# Altium schematic sheet Power.SchDoc(Power)
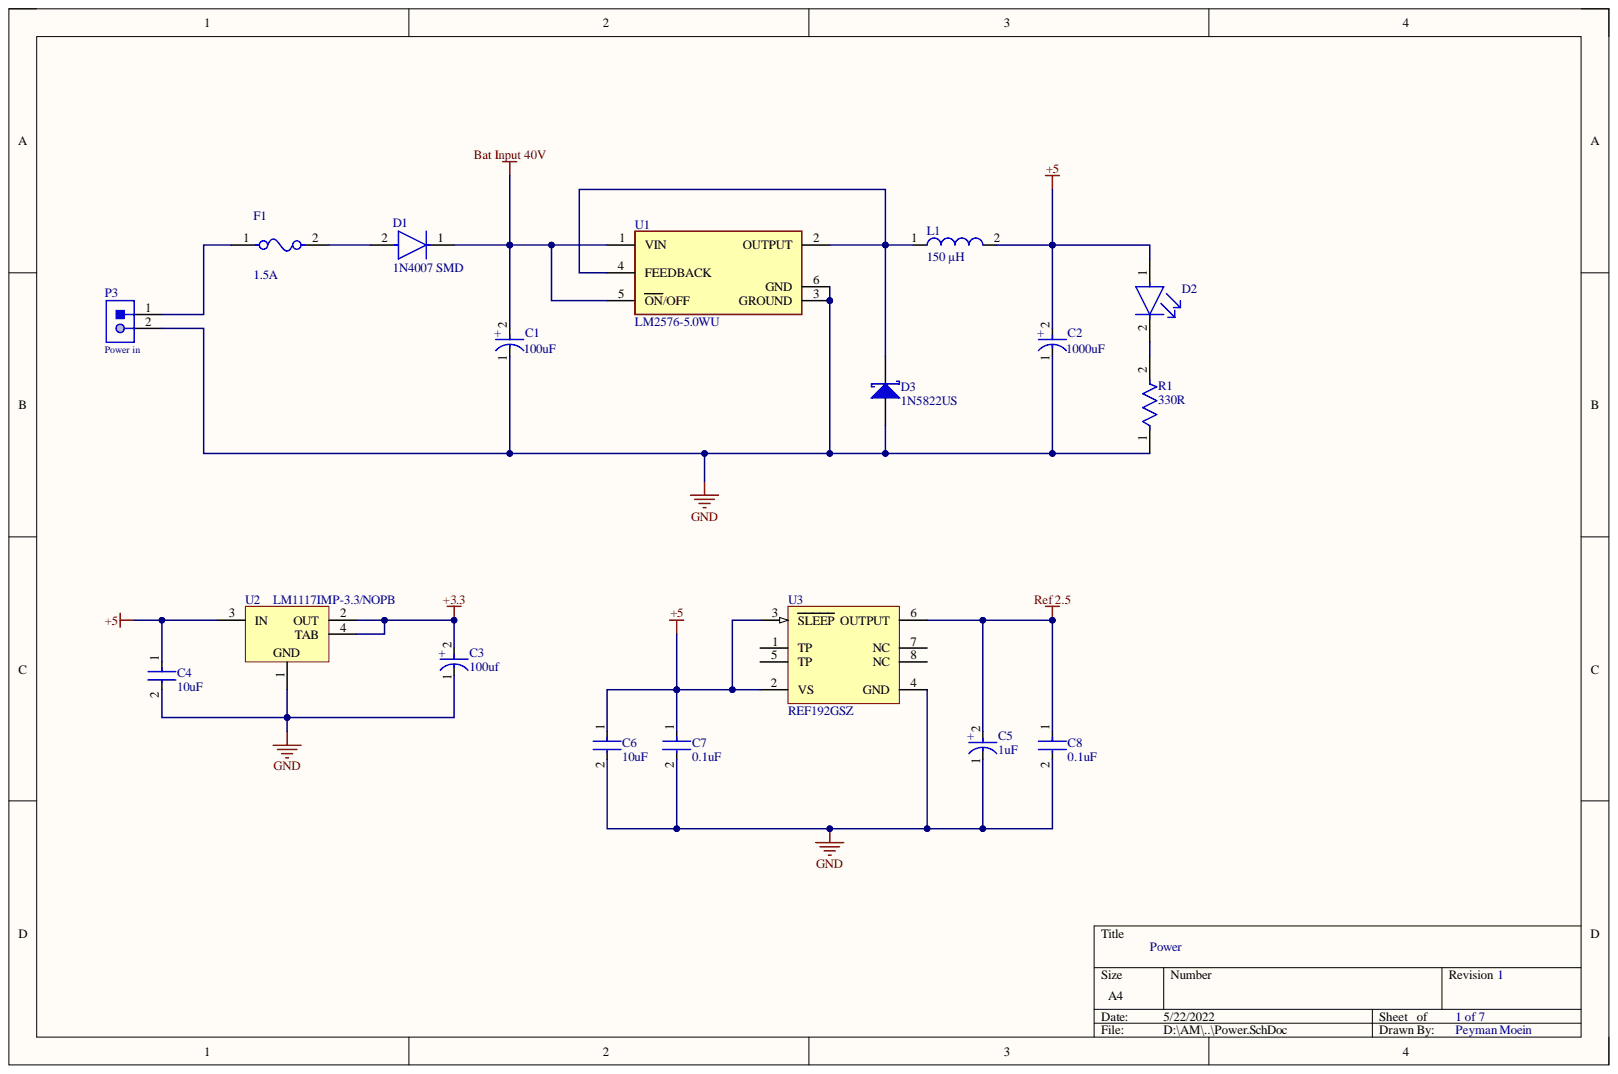
[diagram p0: Power.SchDoc]

## Parts
C1  100uF  [FP-UWT1H101MNL1GS-MFG]
C2  1000uF  [FP-SVPF-F12-MFG]
C3  100uf  [FP-SC-MFG]
C4  10uF  [FP-GRM21B-0_1-IPC_C]
C5  1uF  [FP-T491A105K016AT-MFG]
C6  10uF  [FP-GRM21B-0_1-IPC_C]
C7  0.1uF  [FP-C0805C-DN-MFG]
C8  0.1uF  [FP-C0805C-DN-MFG]
D1  1N4007 SMD  [FP-1N4007_SMD-IPC_C]
D2  APT2012MGC  [FP-APT2012MGC-MFG]  (value not drawn)
D3  1N5822US  [MCSM-D-5B-2_L]
F1  1.5A  [FP-0679H-MFG]
L1  150 µH  [WE-PD-XL]
P3  Power in  [61900219521]
R1  330R  [FP-CRCW0805-e3-MFG]
U1  LM2576-5.0WU  [FP-TO263-5LD-PL-1-MFG]
U2  LM1117IMP-3.3/NOPB  [DCY0004A_N]
U3  REF192GSZ  [ADI-R-8_M]

## Nets
+3.3: C3.2 U2.2(OUT) U2.4(TAB)
+5: C2.2 C4.1 C6.1 C7.1 D2.1 L1.2 U2.3(IN) U3.2(VS) U3.3(SLEEP)
Bat Input 40V: C1.2 D1.1 U1.1(VIN) U1.5(ON/OFF)
GND: C1.1 C2.1 C3.1 C4.2 C5.1 C6.2 C7.2 C8.2 D3.1 P3.2 R1.1 U1.3(GROUND) U1.6(GND) U2.1 U3.4(GND)
Ref 2.5: C5.2 C8.1 U3.6(OUTPUT)
NetD1_2: D1.2 F1.2
NetD2_2: D2.2 R1.2
NetD3_2: D3.2 L1.1 U1.2(OUTPUT) U1.4(FEEDBACK)
NetF1_1: F1.1 P3.1

Not connected: U3 1(TP) 5(TP) 7(NC) 8(NC)

## Library data of the parts
C1 = CMP-2000-07373-2: CAP ALUM 100UF 20% 50V SMD; Dielectric Material: Aluminium; Dissipation Factor: 0.14%; Leakage Current: 3uA; Life (Hours): 1000Hour; Ripple Current: 140mA; Capacitance: 100uF; Case/Package: Radial; Component Kind: Standard; Construction: Cylindrical; Diameter: 8mm; Height: 10mm; Height - Seated (Max): 0.413inch; Lead Free: Lead Free; Length: 10mm; Library Name: Altium Content Vault; Max Operating Temperature: 105°C; Min Operating Temperature: -55°C; Mount: Surface Mount; Number of Pins: 2; Package Quantity: 500; Packaging: Tape and Reel; Radiation Hardening: No; REACH SVHC: No SVHC; Ripple Current (AC): 140mA; RoHS Compliant: Yes; Series: WT; Termination: SMD/SMT; Tolerance: 20%; Voltage: 50V; Voltage Rating: 50V; Voltage Rating (DC): 50V
C2 = CMP-05427-000075-1: CAP ALUM POLY 1000UF 20% 16V SMD; Capacitance: 1mF; Dissipation Factor: 12%; ESR (Equivalent Series Resistance): 12mR; Leakage Current: 3.2mA; Life (Hours): 5000Hour; Polarity: Polar; Ripple Current: 5.4A; Case/Package: Radial; Component Kind: Standard; Diameter: 10mm; Height: 12.6mm; Height - Seated (Max): 0.5inch; Lead Free: Lead Free; Length: 12.6mm; Library Name: Altium Content Vault; Max Operating Temperature: 105°C; Min Operating Temperature: -55°C; Mount: Surface Mount; Number of Pins: 12; Package Quantity: 400; Packaging: Tape and Reel; Radiation Hardening: No; REACH SVHC: No SVHC; Ripple Current (AC): 5.4A; RoHS Compliant: Yes; Termination: SMD/SMT; Tolerance: 20%; Voltage: 16V; Voltage Rating: 16V; Voltage Rating (DC): 16V
C3 = CMP-05427-000047-1: CAP ALUM 100UF 20% 10V SMD; Life (Hours): 1000Hour; Ripple Current: 50mA; Capacitance: 100uF; Case/Package: Radial; Component Kind: Standard; Construction: Cylindrical; Contact Plating: Tin; Diameter: 5mm; Height: 5.4mm; Height - Seated (Max): 0.213inch; Lead Free: Lead Free; Length: 5.5mm; Library Name: Altium Content Vault; Max Operating Temperature: 85°C; Min Operating Temperature: -40°C; Mount: Surface Mount; Package Quantity: 1; Packaging: Tape and Reel; Radiation Hardening: No; Ripple Current (AC): 50mA; RoHS Compliant: Yes; Series: S; Termination: SMD/SMT; Tolerance: 20%; Voltage Rating: 10V; Voltage Rating (DC): 10V
C4 C6 = CMP-2007-03453-2: Chip Multilayer Ceramic Capacitors for General Purpose, 0805, 10uF, X5R, 15%, 10%, 16V; Capacitance: 10uF; Case Code (Imperial): 0805; Case Code (Metric): 2012; Case/Package: 0805; Component Kind: Standard; Depth: 1.25mm; Dielectric: X5R; Height: 1.25mm; Lead Free: Lead Free; Length: 2mm; Library Name: Altium Content Vault; Material: Ceramic; Max Operating Temperature: 85°C; Min Operating Temperature: -55°C; Mount: Surface Mount; Package Quantity: 1; Packaging: Tape and Reel; Radiation Hardening: No; REACH SVHC: No SVHC; Termination: SMD/SMT; Thickness: 0.053inch; Tolerance: 10%; Voltage: 16V; Voltage Rating: 16V; Voltage Rating (DC): 16V; Width: 1.25mm
C5 = CMP-2000-07186-2: CAP TANT 1UF 10% 16V 1206; Dielectric Material: Tantalum; Dissipation Factor: 4%; ESR (Equivalent Series Resistance): 10R; Leakage Current: 500nA; Life (Hours): 2000Hour; Polarity: Polar; Ripple Current: 87mA; Tolerance: 20%; Capacitance: 1uF; Case Code (Imperial): 1206; Case Code (Metric): 3216; Case/Package: 1206; Component Kind: Standard; Depth: 1.6mm; Features: General Purpose; Height: 1.6mm; Height - Seated (Max): 0.071inch; Lead Free: Lead Free; Length: 3.2mm; Library Name: Altium Content Vault; Max Operating Temperature: 125°C; Min Operating Temperature: -55°C; Mount: Surface Mount; Number of Pins: 2; Package Quantity: 1; Packaging: Tape and Reel; REACH SVHC: No SVHC; Termination: SMD/SMT; Voltage: 16V; Voltage Rating: 16V; Voltage Rating (DC): 16V; Width: 1.6mm
C7 C8 = CMP-2007-00279-2: CAP CER 0.1UF 50V X7R 0805; Manufacturer: KEMET; Manufacturer Part Number: C0805C104K5RACTU; Capacitance: 0.10 μF; Material: Ceramic; Temperature Coefficient: 30ppm/°C; Tolerance: 0.1; Value: 0.10 μF; Automotive: No; Category: Cap; Component Kind: Standard; ComponentLink2Description: Datasheet; ComponentLink2URL: https://content.kemet.com/datasheets/KEM_C1003_C0G_SMD.pdf; Device Class L1: Passive Components; Device Class L2: Capacitors; Device Class L3: Ceramic Capacitors; Digikey Description: CAP CER 0.1UF 50V X7R 0805; Height: 0.88mm; Ipc Land Pattern Name: CAPC200125X78; Lead Free: Yes; Library Name: Altium Content Vault; Mouser Description: Cap Ceramic 0.1uF 50V X7R 10% SMD 2.0 x 1.2 mm 125 C Paper T/R; Octopart: https://octopart.com/c0805c104k5ractu-kemet-143926; Package: C0805C-DN; RoHS: TRUE; Temperature: -55°C to +125°C; Temperature Characteristic: X7R; Temperature Range High: +125°C; Temperature Range Low: -55°C; Voltage Rating: 50 V
D1 = CMP-12389-000001-1: Rectifier, 1Kv, 1A, Do-214Ac Rohs Compliant: Yes; Component Kind: Standard; Library Name: Altium Content Vault
D2 = CMP-44529-000017-1: LED GREEN CLEAR CHIP SMD; Dominant Wavelength: 570nm; Forward Current: 20mA; Forward Voltage: 2.1V; Lens Color: Colorless; Luminous Intensity: 70mcd; Power Rating: 75mW; Viewing Angle: 120°; Case Code (Imperial): 0805; Case Code (Metric): 2012; Case/Package: 0805; Color: Green; Component Kind: Standard; Height: 0.75mm; Illumination Color: Green; Lead Free: Lead Free; Length: 2mm; Lens Style: Clear; Lens Transparency: Clear; Library Name: Altium Content Vault; Max Operating Temperature: 85°C; Min Operating Temperature: -40°C; Mount: Surface Mount; Package Quantity: 2000; Packaging: Tape and Reel; Test Current: 20mA; Width: 1.25mm
D3 = CMP-2000-07610-1: Schottky Barrier Rectifier, 3 A, 0.4 V, -65 to 150 degC, 2-Pin MELF (D-5B), Bulk; Manufacturer: MicrosemiCorporation; Current - Average Rectified (Io): 3A; Current - Reverse Leakage @ Vr: 100mA@40V; Speed: FastRecovery=200mA(Io); Voltage - DC Reverse (Vr) (Max): 40V; Voltage - Forward (Vf) (Max) @ If: 500mV@3A; Capacitance @ Vr, F: -; Category: DiscreteSemiconductorProducts; Component Kind: Standard; Datasheet URL: https://www.microsemi.com/document-portal/doc_download/131998-lds-0303-1; Datasheet Version: Rev. 1, 06/2013; Diode Type: Schottky; Family: Diodes-Rectifiers-Single; Library Name: Altium Content Vault; Manufacturer URL: http://www.microsemi.com/; Mounting Type: SurfaceMount; Operating Temperature - Junction: -65°C~125°C; Package / Case: SQ-MELF,B; Package Description: 2-Pin Surface Mount Device, Body 5.4 x 3.62 mm; Package Reference: D-5B-2; Packaging: Bulk; Part Status: Active; Reverse Recovery Time (trr): -; Series: -; Supplier Device Package: B,SQ-MELF
F1 = CMP-16042-000005-1: FUSE BOARD MNT 1.5A 350VAC 72VDC; Approvals: cURus; DC Cold Resistance: 58mR; Fuse Type: Board Mount (Cartridge Style Excluded); Voltage Rating (AC): 350V; Voltage Rating (DC): 72V; Case Code (Imperial): 2410; Case Code (Metric): 6125; Case/Package: 2410; Component Kind: Standard; Current Rating: 1.5A; Library Name: Altium Content Vault; Max Operating Temperature: 125°C; Min Operating Temperature: -55°C; Mount: Surface Mount; Packaging: Tape and Reel; RoHS Compliant: Yes
L1 = CMP-0227-00206-1: SMD-Shielded Power Inductor WE-PD, L = 150 µH; Inductance: 150 ÂµH; Component Kind: Standard; ComponentLink1Description: Manufacturer Link; ComponentLink1URL: http://www.we-online.com; ComponentLink2Description: Datasheet; ComponentLink2URL: http://www.we-online.de/katalog/media/pdf/744770215.pdf; DatasheetVersion: ver 1, Jan-2006; Library Name: Altium Content Vault; PackageDescription: SMD Inductor, 2-Leads, Body 12.0 x 12.0 mm; PackageReference: WE-PD-XL; PackageVersion: 2004
P3 = CMP-1502-00599-1: Male Top Locking Header WR-WTB, THT, Right Angled, pitch 2.54 mm, 1 x 2 position; Manufacturer: Wurth Elektronik; Contact Resistance: 20mOhm; Pitch: 2.54mm; Position: 1x2; Working Voltage: 250VAC; Component Kind: Standard; Datasheet URL: https://katalog.we-online.de/em/datasheet/6190xx19521.pdf; Gender: Male; Library Name: Altium Content Vault; Manufacturer URL: http://www.we-online.de; Mounting Technology: THT; Operating Temperature: -40to105degC; Orientation: RightAngled; Package Reference: 61900219521; Packaging: Bag; Part Number: 61900219521; Publisher: WurthElektronik
R1 = CMP-02407-004193-1: RES Thick Film, 2.94Ω, 1%, 0.125W, 100ppm/°C, 0805; Max Power Dissipation: 125mW; Resistance: 2.94R; Voltage Rating: 150V; Case Code (Imperial): 0805; Case Code (Metric): 2012; Case/Package: 0805; Component Kind: Standard; Composition: Thick Film; Contact Plating: Tin; Depth: 1.25mm; Features: Automotive AEC-Q200; Height: 0.02inch; Lead Free: Lead Free; Length: 2mm; Library Name: Altium Content Vault; Max Operating Temperature: 155°C; Military Standard: Not; Min Operating Temperature: -55°C; Number of Terminations: 2; Package Quantity: 10; Packaging: Tape and Reel; Power Rating: 125mW; Radiation Hardening: No; Temperature Coefficient: 100ppm/°C; Termination: SMD/SMT; Tolerance: 1%; Weight: 0.000194oz; Width: 0.049inch
U1 = CMP-06325-000018-1: IC REG BUCK 5V 3A TO263-5; Max Input Voltage: 40V; Min Input Voltage: 4V; Number of Outputs: 1; Output Configuration: Positive; Output Type: Fixed; Switching Frequency: 52kHz; Synchronous Rectifier: No; Case/Package: TO-263-6; Component Kind: Standard; Lead Free: Lead Free; Library Name: Altium Content Vault; Max Operating Temperature: 125°C; Min Operating Temperature: -40°C; Min Output Voltage: 5V; Mount: Surface Mount; Nominal Output Voltage: 5V; Number of Pins: 5; Output Current: 3A; Output Voltage: 5V; Packaging: Bulk; REACH SVHC: No SVHC
U2 = CMP-0062-01631-4: 800mA Low-Dropout Linear Regulator, 4-pin SOT-223, Pb-Free; Manufacturer: Texas Instruments; CompType: LDO IC; High-Reliability: Y; PackageTopMarking: XYTT*N05B; PowerWise: N; Code_IPC: SOT223-4; Code_JEDEC: TO-261-AA; Component Kind: Standard; ComponentLink1Description: Datasheet URL; ComponentLink1URL: http://www.ti.com/general/docs/lit/getliterature.tsp?genericPartNumber=LM1117-N&fileType=pdf; Library Name: Altium Content Vault; PackageDescription: Molded SOT-223, 6.50 x 3.56 x 1.6mm Body, 4 Lead, 2.3mm Pitch; PackageReference: DCY0004A; PartNumber: LM1117IMP-3.3/NOPB; PinCount: 4; RoHS: Y
U3 = CMP-0784-00133-1: Precision Micropower, Low Dropout Voltage Reference, 2.5 V Output, 2.6 to 15 V Supply, Industrial, 8-pin SOIC (R-8), Tube; Manufacturer: Analog Devices; Ref Out TC (ppm/C): 10; Vout: 2.5V; Code_JEDEC: MS-012-AA; Component Kind: Standard; ComponentLink1Description: Manufacturer URL; ComponentLink1URL: http://www.analog.com/en/index.html; ComponentLink2Description: Datasheet; ComponentLink2URL: http://www.analog.com/static/imported-files/data_sheets/REF19xSeries.pdf; ComponentLink3Description: Package Specification; ComponentLink3URL: http://www.analog.com/static/imported-files/packages/PKG_PDF/SOIC_Narrow%20(R)/R_8.pdf; ComponentLink4Description: URL Link1; ComponentLink4URL: Product URL:http://www.analog.com/en/special-linear-functions/voltage-references/ref192/products/product.html; DatasheetVersion: Rev. L; Library Name: Altium Content Vault; Mounting Technology: Surface Mount; Operating Temp Range: -40 to 85 degC; PackageDescription: 8-Pin Standard Small Outline Package, Body 4.9 x 3.9 mm, Pitch 1.27 mm; PackageReference: R-8; PackageVersion: 060506-A, 06/2006; Packing: Tube; PartNumber: REF192GSZ
